# Altium schematic sheet 19 WIFI.SchDoc
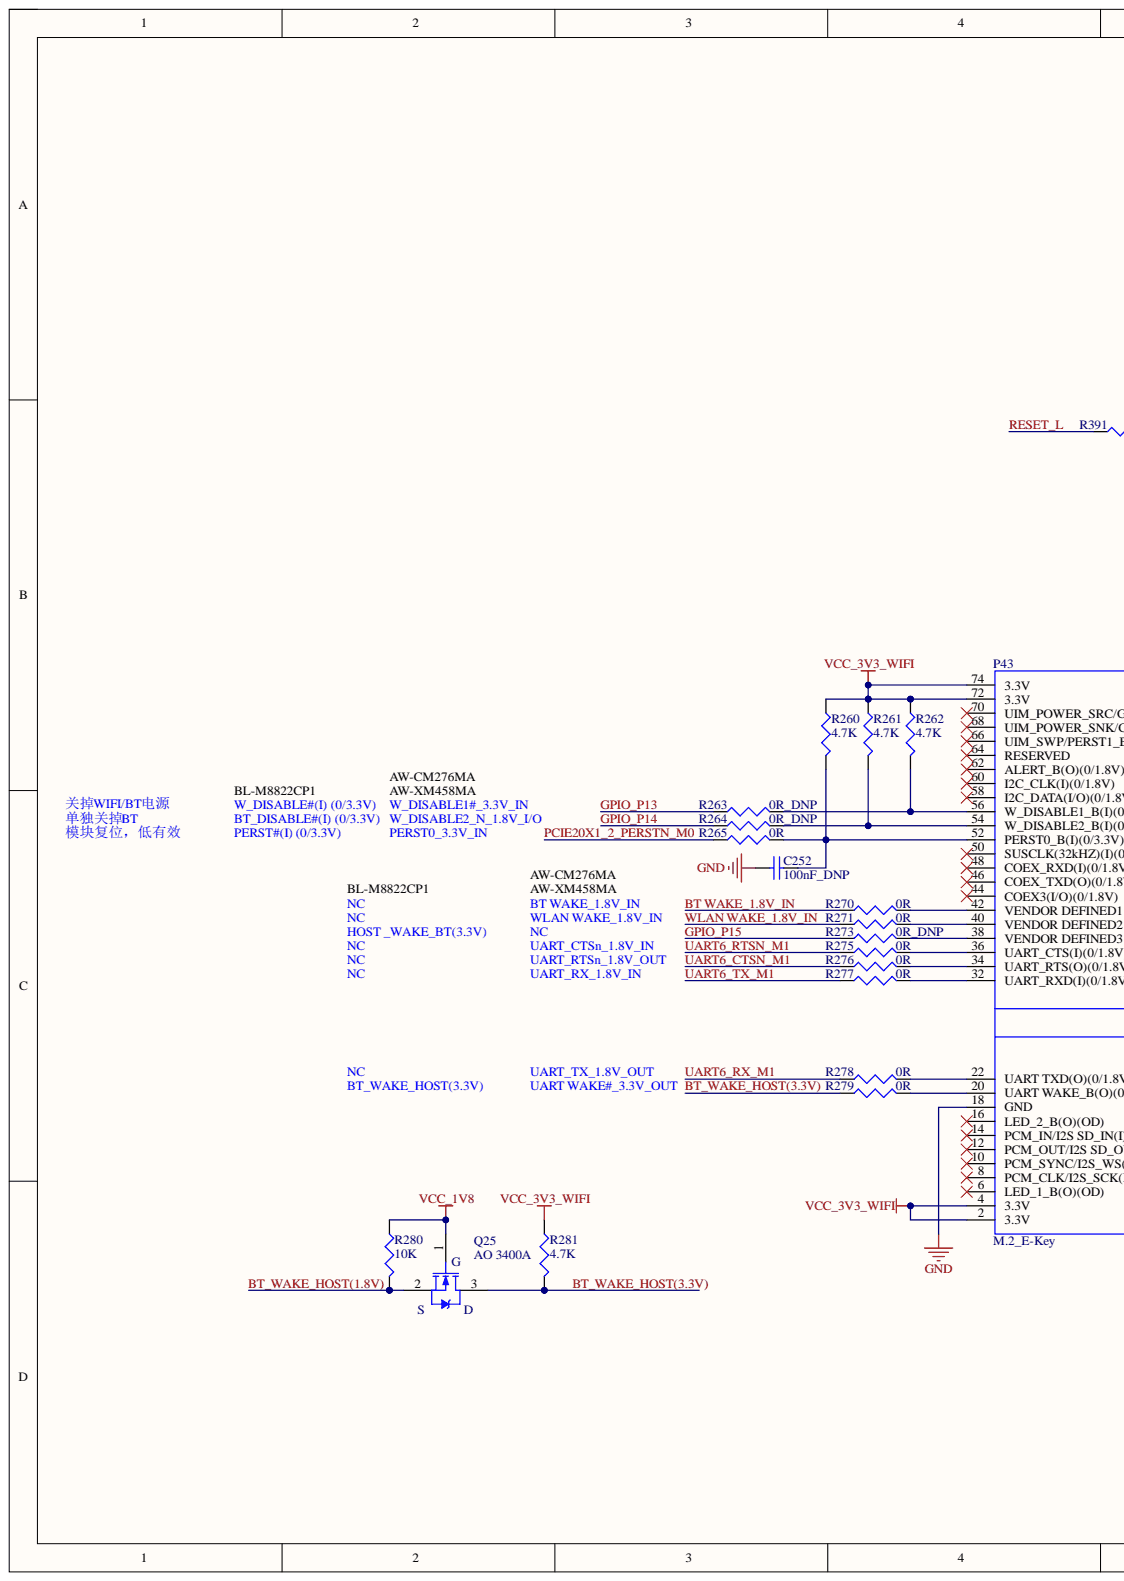
[diagram p0: 19 WIFI.SchDoc, left]
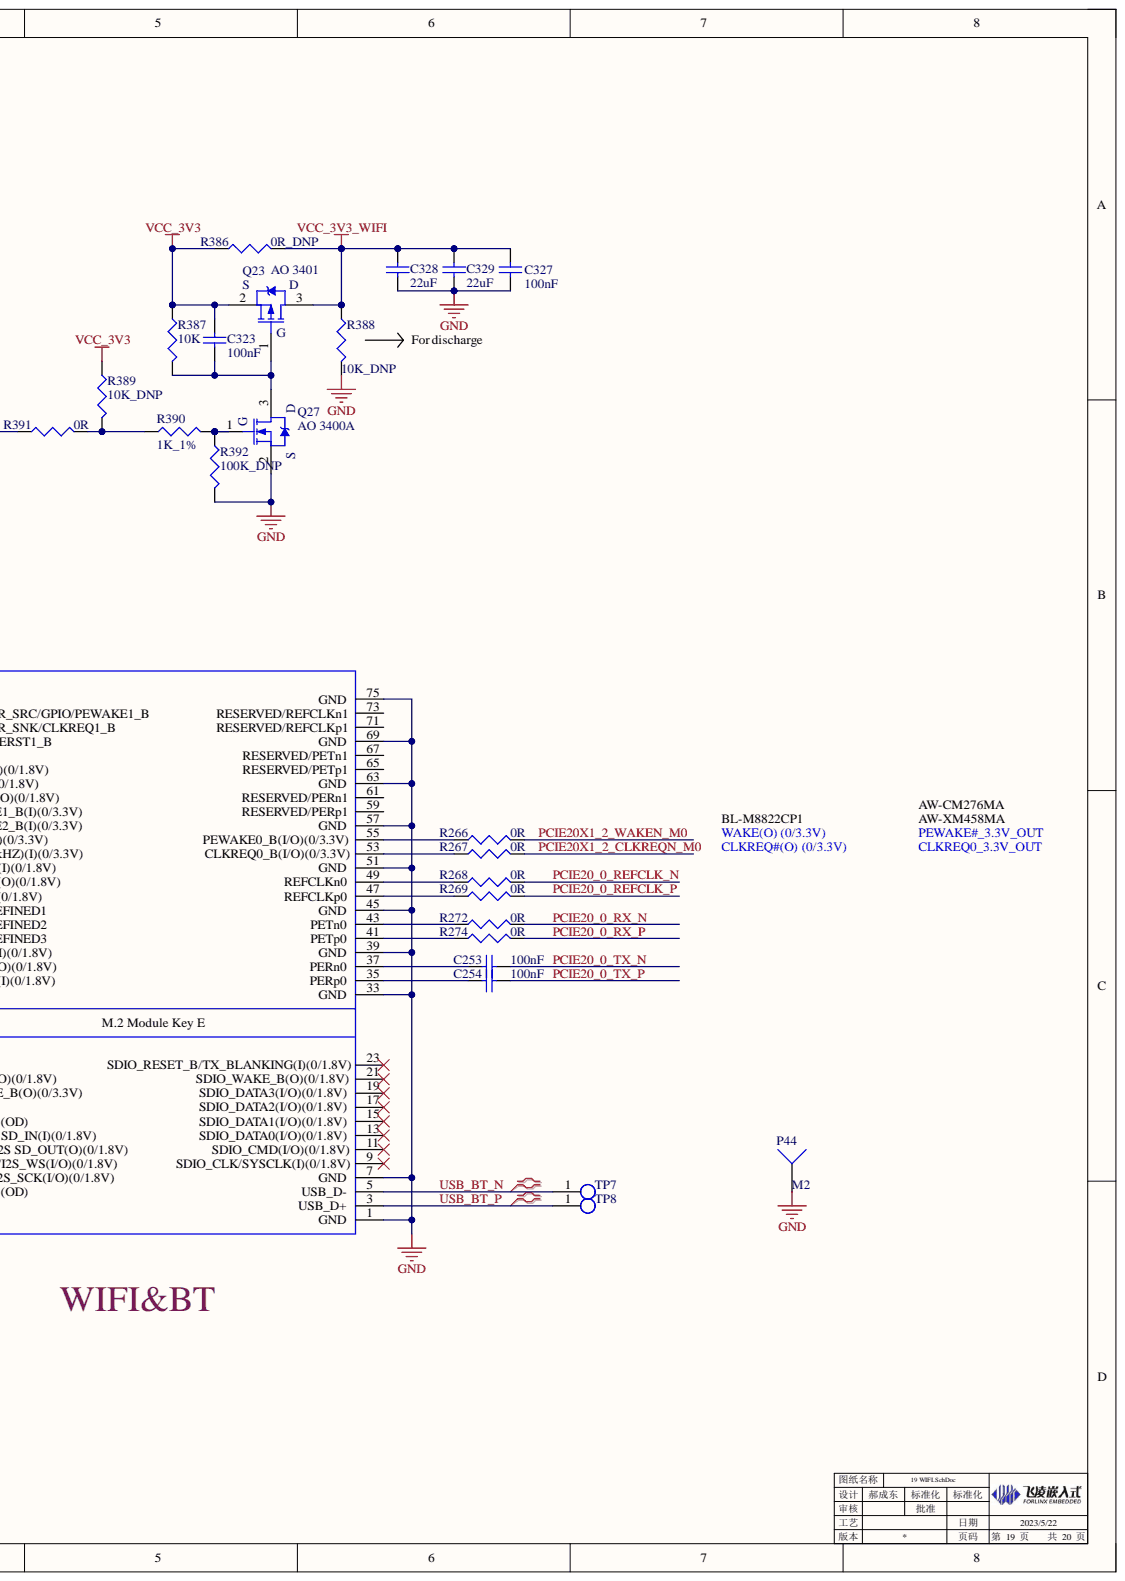
[diagram p1: 19 WIFI.SchDoc, right]

## Parts
C252  100nF_DNP  [0402C]
C253  100nF  [0402C]
C254  100nF  [0402C]
C323  100nF  [0402C]
C327  100nF  [0402C]
C328  22uF  [0805C]
C329  22uF  [0805C]
P43  M.2_E-Key  [M.2_E-Key]
P44  M2  [HOLE3.6-5.6]
Q23  AO 3401  [SOT_233_W]
Q25  AO 3400A  [SOT_233_W]
Q27  AO 3400A  [SOT_233_W]
R260  4.7K  [0402R]
R261  4.7K  [0402R]
R262  4.7K  [0402R]
R263  0R_DNP  [0402R]
R264  0R_DNP  [0402R]
R265  0R  [0402R]
R266  0R  [0402R]
R267  0R  [0402R]
R268  0R  [0402R]
R269  0R  [0402R]
R270  0R  [0402R]
R271  0R  [0402R]
R272  0R  [0402R]
R273  0R_DNP  [0402R]
R274  0R  [0402R]
R275  0R  [0402R]
R276  0R  [0402R]
R277  0R  [0402R]
R278  0R  [0402R]
R279  0R  [0402R]
R280  10K  [0402R]
R281  4.7K  [0402R]
R386  0R_DNP  [0402R]
R387  10K  [0402R]
R388  10K_DNP  [0402R]
R389  10K_DNP  [0402R]
R390  1K_1%  [0402R]
R391  0R  [0402R]
R392  100K_DNP  [0402R]
TP7  TEST POINT  [TP]  (value not drawn)
TP8  TEST POINT  [TP]  (value not drawn)

## Nets
GND: C252.2 C327.1 C328.1 C329.1 P43.1(GND) P43.7(GND) P43.18(GND) P43.33(GND) P43.39(GND) P43.45(GND) P43.51(GND) P43.57(GND) P43.63(GND) P43.69(GND) P43.75(GND) P44.1 Q27.2 R388.2 R392.2
VCC_1V8: Q25.1 R280.1
VCC_3V3: C323.2 Q23.2 R386.1 R387.1 R389.1
VCC_3V3_WIFI: C327.2 C328.2 C329.2 P43.2(3.3V) P43.4(3.3V) P43.72(3.3V) P43.74(3.3V) Q23.3 R260.1 R261.1 R262.1 R281.1 R386.2 R388.1
BT WAKE_1.8V_IN: R270.1
BT_WAKE_HOST(1.8V): Q25.2 R280.2
BT_WAKE_HOST(3.3V): Q25.3 R279.1 R281.2
GPIO_P13: R263.1
GPIO_P14: R264.1
GPIO_P15: R273.1
PCIE20_0_REFCLK_N: R268.2
PCIE20_0_REFCLK_P: R269.2
PCIE20_0_RX_N: R272.2
PCIE20_0_RX_P: R274.2
PCIE20_0_TX_N: C253.2
PCIE20_0_TX_P: C254.2
PCIE20X1_2_CLKREQN_M0: R267.2
PCIE20X1_2_PERSTN_M0: R265.1
PCIE20X1_2_WAKEN_M0: R266.2
RESET_L: R391.1
UART6_CTSN_M1: R276.1
UART6_RTSN_M1: R275.1
UART6_RX_M1: R278.1
UART6_TX_M1: R277.1
USB_BT_N: P43.5(USB_D-) TP7.1
USB_BT_P: P43.3(USB_D+) TP8.1
WLAN WAKE_1.8V_IN: R271.1
NetC252_1: C252.1 P43.52(PERST0_B(I)(0/3.3V)) R260.2 R265.2
NetC253_1: C253.1 P43.37(PERn0)
NetC254_1: C254.1 P43.35(PERp0)
NetC323_1: C323.1 Q23.1 Q27.3 R387.2
NetP43_20: P43.20(UART_WAKE_B(O)(0/3.3V)) R279.2
NetP43_22: P43.22(UART_TXD(O)(0/1.8V)) R278.2
NetP43_32: P43.32(UART_RXD(I)(0/1.8V)) R277.2
NetP43_34: P43.34(UART_RTS(O)(0/1.8V)) R276.2
NetP43_36: P43.36(UART_CTS(I)(0/1.8V)) R275.2
NetP43_38: P43.38(VENDOR_DEFINED3) R273.2
NetP43_40: P43.40(VENDOR_DEFINED2) R271.2
NetP43_41: P43.41(PETp0) R274.1
NetP43_42: P43.42(VENDOR_DEFINED1) R270.2
NetP43_43: P43.43(PETn0) R272.1
NetP43_47: P43.47(REFCLKp0) R269.1
NetP43_49: P43.49(REFCLKn0) R268.1
NetP43_53: P43.53(CLKREQ0_B(I/O)(0/3.3V)) R267.1
NetP43_54: P43.54(W_DISABLE2_B(I)(0/3.3V)) R261.2 R264.2
NetP43_55: P43.55(PEWAKE0_B(I/O)(0/3.3V)) R266.1
NetP43_56: P43.56(W_DISABLE1_B(I)(0/3.3V)) R262.2 R263.2
NetQ27_1: Q27.1 R390.2 R392.1
NetR389_2: R389.2 R390.1 R391.2

Not connected: P43 6(LED_1_B(O)(OD)) 8(PCM_CLK/I2S_SCK(I/O)(0/1.8V)) 9(SDIO_CLK/SYSCLK(I)(0/1.8V)) 10(PCM_SYNC/I2S_WS(I/O)(0/1.8V)) 11(SDIO_CMD(I/O)(0/1.8V)) 12(PCM_OUT/I2S_SD_OUT(O)(0/1.8V)) 13(SDIO_DATA0(I/O)(0/1.8V)) 14(PCM_IN/I2S_SD_IN(I)(0/1.8V)) 15(SDIO_DATA1(I/O)(0/1.8V)) 16(LED_2_B(O)(OD)) 17(SDIO_DATA2(I/O)(0/1.8V)) 19(SDIO_DATA3(I/O)(0/1.8V)) 21(SDIO_WAKE_B(O)(0/1.8V)) 23(SDIO_RESET_B/TX_BLANKING(I)(0/1.8V)) 44(COEX3(I/O)(0/1.8V)) 46(COEX_TXD(O)(0/1.8V)) 48(COEX_RXD(I)(0/1.8V)) 50(SUSCLK(32kHZ)(I)(0/3.3V)) 58(I2C_DATA(I/O)(0/1.8V)) 59(RESERVED/PERp1) 60(I2C_CLK(I)(0/1.8V)) 61(RESERVED/PERn1) 62(ALERT_B(O)(0/1.8V)) 64(RESERVED) 65(RESERVED/PETp1) 66(UIM_SWP/PERST1_B) 67(RESERVED/PETn1) 68(UIM_POWER_SNK/CLKREQ1_B) 70(UIM_POWER_SRC/GPIO/PEWAKE1_B) 71(RESERVED/REFCLKp1) 73(RESERVED/REFCLKn1)

## Library data of the parts
C252-C254 C323 C327 = 1110202039: Database Table Name: VALID$; 存货名称: 贴装叠层陶瓷电容; 序号: 626; 规格型号: 0402,100nF,25V,±10％,X5R; Component Kind: Standard; LatestRevisionDate: 17-Jul-2002; LatestRevisionNote: Re-released for DXP Platform.; Library Name: Database_AD Library.DbLib; PackageDescription: Radial Cap, Thru-Hole; 2 Leads; 0.3 in Pin Spacing; PackageReference: RAD-0.3; Published: 8-Jun-2000; Signal Integrity: Cap; Simulation: CAP; 存货编码: 1110202039; Publisher: Altium Limited
  C252: Value: 100nF_DNP
  C253 C254 C323 C327: Value: 100nF
C328 C329 = 1110204012: Database Table Name: VALID$; 主计量单位名称: 个; 存货名称: 贴装叠层陶瓷电容; 安全库存: 6299; 序号: 747; 旧编码: 010203017; 旧规格型号: 0805 22uF 16V ±20％ X5R; 规格型号: 0805,22uF,16V,±20％,X5R; Component Kind: Standard; LatestRevisionDate: 17-Jul-2002; LatestRevisionNote: Re-released for DXP Platform.; Library Name: Database_AD Library.DbLib; PackageDescription: Radial Cap, Thru-Hole; 2 Leads; 0.3 in Pin Spacing; PackageReference: RAD-0.3; Published: 8-Jun-2000; Signal Integrity: Cap; Simulation: CAP; Value: 22uF; 存货编码: 1110204012; Publisher: Altium Limited
P43 = 1120201188: Database Table Name: VALID$; 存货名称: 插槽; 规格型号: M.2,0.5PITCH,H3,KEY E; Component Kind: Standard; Library Name: Database_AD Library.DbLib; Value: M.2_E-Key; 存货编码: 1120201188
P44 = 1150303066: Database Table Name: VALID$; 存货名称: 贴片螺母; 规格型号: M2mm,长1.5mm; Component Kind: Standard; LatestRevisionDate: 17-Jul-2002; LatestRevisionNote: Re-released for DXP Platform.; Library Name: Database_AD Library.DbLib; Signal Integrity: Connector; Value: M2; 存货编码: 1150303066; Publisher: Altium Limited
Q23 = 1110505019: Database Table Name: VALID$; Note: Pin 2 is electrically connected to pin 4.; 存货名称: 场效应管; 序号: 2675; 规格型号: AO3401,SOT-23-3,P沟道; Component Kind: Standard; ComponentLink1Description: Manufacturer Link; ComponentLink1URL: http://www.irf.com/; DatasheetVersion: PD-9.814; LatestRevisionDate: 17-Jul-2002; LatestRevisionNote: Re-released for DXP Platform.; Library Name: Database_AD Library.DbLib; PackageDescription: TO, Thru-Hole, Vertical, Heatsink Mounted; 3 In-Line Leads; Pitch 2.54 mm; PackageReference: TO-220AB; PackageVersion: Feb-1997; Published: 8-Jun-2000; Simulation: IRF1010; VALUE: AO 3401; 存货编码: 1110505019; Publisher: Altium Limited
Q25 Q27 = 1110505027: Database Table Name: VALID$; Note: Pin 2 is electrically connected to pin 4.; 存货名称: 场效应管; 描述栏信息: 替换1110505011; 规格型号: AO3400A,SOT23,N沟道; Component Kind: Standard; DatasheetVersion: PD-9.814; LatestRevisionDate: 17-Jul-2002; LatestRevisionNote: Re-released for DXP Platform.; Library Name: Database_AD Library.DbLib; PackageDescription: TO, Thru-Hole, Vertical, Heatsink Mounted; 3 In-Line Leads; Pitch 2.54 mm; PackageReference: TO-220AB; PackageVersion: Feb-1997; Published: 8-Jun-2000; Simulation: IRF1010; VALUE: AO 3400A; 存货编码: 1110505027; Publisher: Altium Limited
R260-R262 R281 = 1110102003: Database Table Name: VALID$; 存货名称: 贴装电阻; 序号: 12; 规格型号: 0402,4.7K,±5％; Component Kind: Standard; Library Name: Database_AD Library.DbLib; Signal Integrity: Res; Simulation: RESISTOR; Value: 4.7K; 存货编码: 1110102003
R263-R279 R386 R391 = 1110102001: Database Table Name: VALID$; 存货名称: 贴装电阻; 序号: 10; 规格型号: 0402,0Ω,±5％; Component Kind: Standard; Library Name: Database_AD Library.DbLib; Signal Integrity: Res; Simulation: RESISTOR; 存货编码: 1110102001
  R263 R264 R273 R386: Value: 0R_DNP
  R265-R272 R274-R279 R391: Value: 0R
R280 R387-R389 = 1110102007: Database Table Name: VALID$; 存货名称: 贴装电阻; 序号: 17; 规格型号: 0402,10K,±5％; Component Kind: Standard; Library Name: Database_AD Library.DbLib; Signal Integrity: Res; Simulation: RESISTOR; 存货编码: 1110102007
  R280 R387: Value: 10K
  R388 R389: Value: 10K_DNP
R390 = 1110102011: Database Table Name: VALID$; 存货名称: 贴装电阻; 序号: 21; 规格型号: 0402,1K,±1％; Component Kind: Standard; Library Name: Database_AD Library.DbLib; Signal Integrity: Res; Simulation: RESISTOR; Value: 1K_1%; 存货编码: 1110102011
R392 = 1110102004: Database Table Name: VALID$; 存货名称: 贴装电阻; 序号: 14; 规格型号: 0402,100K,±5％; Component Kind: Standard; Library Name: Database_AD Library.DbLib; Signal Integrity: Res; Simulation: RESISTOR; Value: 100K_DNP; 存货编码: 1110102004
TP7 TP8 = TEST POINT: Database Table Name: Other$; 存货编码: 0; 序号: 61; Component Kind: Standard; Library Name: Database_AD Library.DbLib; Value: TEST POINT; 安全库存: 0
